# Altium schematic sheet Controller Board - Connectors.SchDoc("Controller Board - Connectors")
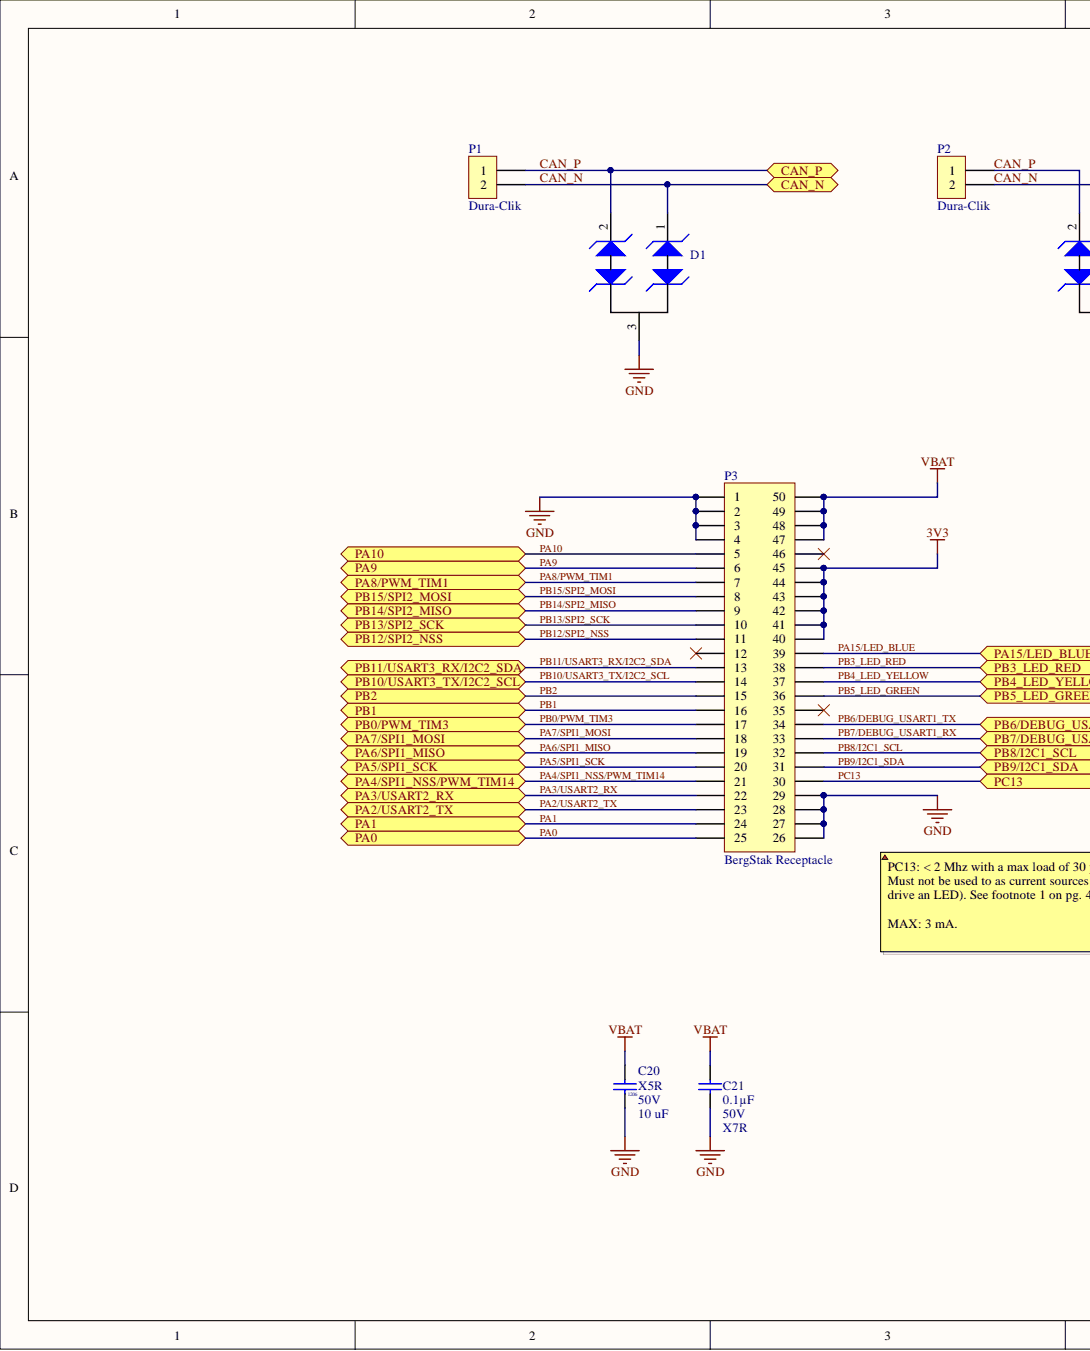
[diagram p0: Controller Board - Connectors.SchDoc, left]
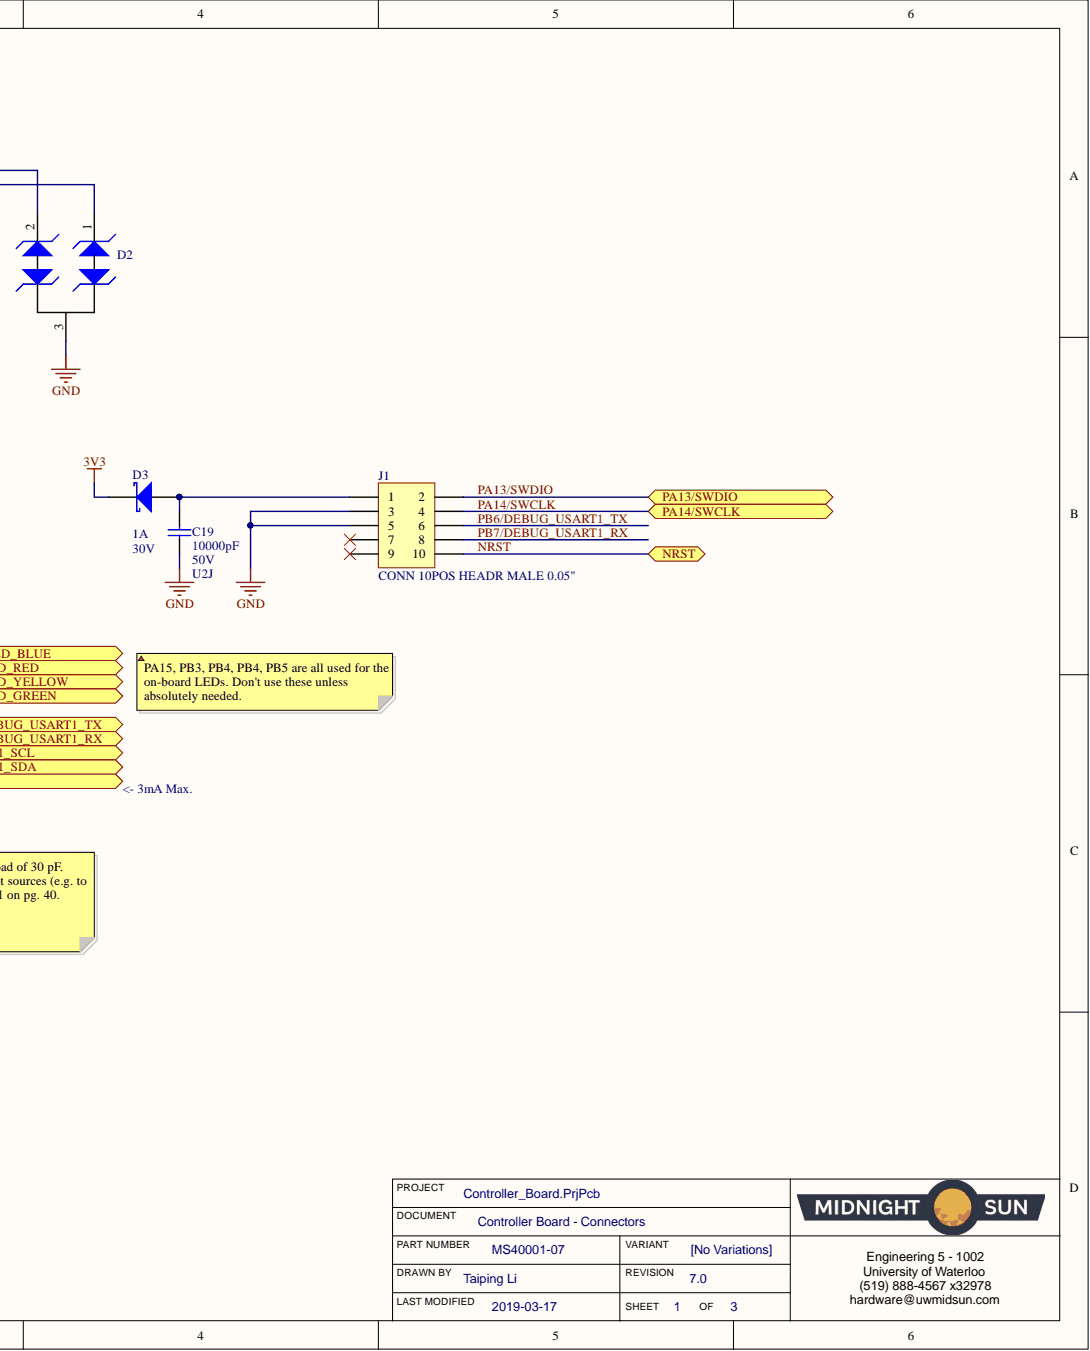
[diagram p1: Controller Board - Connectors.SchDoc, right]

## Parts
C19  Voltage: 50V  Temperature Coefficient: U2J  Capacitance: 10000pF  [CAP, 0603]  (hidden comment: CAP CER 10nF 50V 5% X7R 0603)
C20  Voltage Rating: 50V  Rating: X5R  Case Code (Imperial): 1206  Capacitance: 10 uF  [CAP, 1206]  (hidden comment: CAP CER 10uF 50V 20% X5R 1206)
C21  Voltage: 50V  Temperature Coefficient: X7R  Capacitance: 0.1µF  [CAP, 0603]  (hidden comment: CAP CER 0.1UF 50V 10% X7R 0603)
D1  DIODE TVS 24VWM 70VC SOT23  [MOSFET P-CH 30V 3.8A SOT-23]  (value not drawn)
D2  DIODE TVS 24VWM 70VC SOT23  [MOSFET P-CH 30V 3.8A SOT-23]  (value not drawn)
D3  Current - Average Rectified (Io): 1A  [DIODE SCHOTTKY 30V 1A POWERDI123]  (hidden comment: DIODE SCHOTTKY 30V 1A POWERDI123)
J1  CONN 10POS HEADR MALE 0.05"  [CONN, 10POS 0.05" Debug Header]
P1  Dura-Clik  [CONN, 2POS Dura-Click Vertical]
P2  Dura-Clik  [CONN, 2POS Dura-Click Vertical]
P3  Series: BergStak Receptacle  [CONN, Bergstak 50 Pos Receptacle]  (hidden comment: CONN 50POS Bergstak Receptacle 0.02")

## Ports
CAN_N, CAN_P, NRST, PA0, PA1, PA2/USART2_TX, PA3/USART2_RX, PA4/SPI1_NSS/PWM_TIM14, PA5/SPI1_SCK, PA6/SPI1_MISO, PA7/SPI1_MOSI, PA8/PWM_TIM1, PA9, PA10, PA13/SWDIO, PA14/SWCLK, PA15/LED_BLUE, PB0/PWM_TIM3, PB1, PB2, PB3_LED_RED, PB4_LED_YELLOW, PB5_LED_GREEN, PB6/DEBUG_USART1_TX, PB7/DEBUG_USART1_RX, PB8/I2C1_SCL, PB9/I2C1_SDA, PB10/USART3_TX/I2C2_SCL, PB11/USART3_RX/I2C2_SDA, PB12/SPI2_NSS, PB13/SPI2_SCK, PB14/SPI2_MISO, PB15/SPI2_MOSI, PC13

## Nets
3V3: D3.2 P3.40 P3.41 P3.42 P3.43 P3.44 P3.45
GND: C19.2 C20.2 C21.2 D1.3 D2.3 J1.3 J1.5 P3.1 P3.2 P3.3 P3.4 P3.26 P3.27 P3.28 P3.29
VBAT: C20.1 C21.1 P3.47 P3.48 P3.49 P3.50
CAN_N: D1.1 D2.1 P1.2 P2.2 ; port CAN_N
CAN_P: D1.2 D2.2 P1.1 P2.1 ; port CAN_P
NRST: J1.10 ; port NRST
PA0: P3.25 ; port PA0
PA1: P3.24 ; port PA1
PA2/USART2_TX: P3.23 ; port PA2/USART2_TX
PA3/USART2_RX: P3.22 ; port PA3/USART2_RX
PA4/SPI1_NSS/PWM_TIM14: P3.21 ; port PA4/SPI1_NSS/PWM_TIM14
PA5/SPI1_SCK: P3.20 ; port PA5/SPI1_SCK
PA6/SPI1_MISO: P3.19 ; port PA6/SPI1_MISO
PA7/SPI1_MOSI: P3.18 ; port PA7/SPI1_MOSI
PA8/PWM_TIM1: P3.7 ; port PA8/PWM_TIM1
PA9: P3.6 ; port PA9
PA10: P3.5 ; port PA10
PA13/SWDIO: J1.2 ; port PA13/SWDIO
PA14/SWCLK: J1.4 ; port PA14/SWCLK
PA15/LED_BLUE: P3.39 ; port PA15/LED_BLUE
PB0/PWM_TIM3: P3.17 ; port PB0/PWM_TIM3
PB1: P3.16 ; port PB1
PB2: P3.15 ; port PB2
PB3_LED_RED: P3.38 ; port PB3_LED_RED
PB4_LED_YELLOW: P3.37 ; port PB4_LED_YELLOW
PB5_LED_GREEN: P3.36 ; port PB5_LED_GREEN
PB6/DEBUG_USART1_TX: J1.6 P3.34 ; port PB6/DEBUG_USART1_TX
PB7/DEBUG_USART1_RX: J1.8 P3.33 ; port PB7/DEBUG_USART1_RX
PB8/I2C1_SCL: P3.32 ; port PB8/I2C1_SCL
PB9/I2C1_SDA: P3.31 ; port PB9/I2C1_SDA
PB10/USART3_TX/I2C2_SCL: P3.14 ; port PB10/USART3_TX/I2C2_SCL
PB11/USART3_RX/I2C2_SDA: P3.13 ; port PB11/USART3_RX/I2C2_SDA
PB12/SPI2_NSS: P3.11 ; port PB12/SPI2_NSS
PB13/SPI2_SCK: P3.10 ; port PB13/SPI2_SCK
PB14/SPI2_MISO: P3.9 ; port PB14/SPI2_MISO
PB15/SPI2_MOSI: P3.8 ; port PB15/SPI2_MOSI
PC13: P3.30 ; port PC13
NetC19_1: C19.1 D3.1 J1.1

Not connected: J1 7 9; P3 12 35 46

## Library data of the parts
C19 = CAP CER 10nF 50V 5% X7R 0603: 10000pF ±5% 50V Ceramic Capacitor U2J 0603 (1608 Metric); Manufacturer Part Number 1: C0603C103J5JAC7867; Manufacturer 1: KEMET; Tolerance: ±5%; Thickness (Max): 0.034" (0.87mm); Supplier Part Number 1: 399-13384-1-ND; Supplier 1: Digi-Key; Size / Dimension: 0.063" L x 0.032" W (1.60mm x 0.80mm); Ratings: -; Part Status: Active; Packaging: Cut Tape (CT); Package / Case: 0603 (1608 Metric); Operating Temperature: -55°C ~ 125°C; Mounting Type: Surface Mount, MLCC; Lead Style: -; Lead Spacing: -; Height - Seated (Max): -; Failure Rate: -; ComponentLink1URL: http://www.kemet.com/docfinder?Partnumber=C0603C103J5JAC7867; ComponentLink1Description: http://www.kemet.com/docfinder?Partnumber=C0603C103J5JAC7867; Component Kind: Standard; Library Name: Schematic Diagrams.SchLib
C20 = CAP CER 10uF 50V 20% X5R 1206: 10µF ±20% 50V Ceramic Capacitor X5R 1206 (3216 Metric); Manufacturer Part Number: GRT31CR61H106ME01L; Manufacturer: Murata; Width: 0.063inch; Tolerance: 20%; Thickness: 0.071inch; Supplier Part Number 1: 490-12457-1-ND; Supplier 1: Digi-Key; Stock 1: 449467; RoHS: Yes; Ratings: AEC-Q200; Pricing 1: 1 = 0.57000, 10 = 0.39800, 100 = 0.23910, 500 = 0.17930, 1000 = 0.15141 (USD); Packaging: Tape and Reel; Mount: Surface Mount; Min Operating Temperature: -55°C; Max Operating Temperature: 85°C; Length: 0.126inch; Component Kind: Standard; Case Code (Metric): 3216; Case/Package: 1206; Library Name: Schematic Diagrams.SchLib
C21 = CAP CER 0.1UF 50V 10% X7R 0603: 0.10µF ±10% 50V Ceramic Capacitor X7R 0603 (1608 Metric); Manufacturer Part Number 1: 06035C104KAT2A; Manufacturer 1: AVX Corporation; Tolerance: ±10%; Thickness (Max): 0.035" (0.90mm); Supplier Part Number 1: 478-5052-1-ND; Supplier 1: Digi-Key; Size / Dimension: 0.063" L x 0.032" W (1.60mm x 0.81mm); Ratings: -; Part Status: Active; Packaging: Cut Tape (CT); Package / Case: 0603 (1608 Metric); Operating Temperature: -55°C ~ 125°C; Mounting Type: Surface Mount, MLCC; Lead Style: -; Lead Spacing: -; Height - Seated (Max): -; ComponentLink1URL: http://datasheets.avx.com/X7RDielectric.pdf; ComponentLink1Description: http://datasheets.avx.com/X7RDielectric.pdf; Component Kind: Standard; Library Name: Schematic Diagrams.SchLib
D1 D2 = DIODE TVS 24VWM 70VC SOT23: TVS DIODE 24VWM 70VC SOT23; Manufacturer Part Number 1: PESD1CAN,215; Manufacturer 1: Nexperia USA Inc.; Voltage - Clamping (Max) @ Ipp: 70V; Voltage - Breakdown (Min): 25.4V; Type: Zener; Power Line Protection: No; Power - Peak Pulse: 200W; Current - Peak Pulse (10/1000µs): 3A (8/20µs); Capacitance @ Frequency: 11pF @ 1MHz; Supplier Part Number 1: 1727-3817-1-ND; Supplier Device Package: SOT-23 (TO-236AB); Supplier 1: Digi-Key; Part Status: Active; Packaging: Cut Tape (CT); Package / Case: TO-236-3, SC-59, SOT-23-3; Operating Temperature: -65°C ~ 150°C (TA); Mounting Type: Surface Mount; ComponentLink1URL: http://assets.nexperia.com/documents/data-sheet/PESD1CAN.pdf; ComponentLink1Description: http://assets.nexperia.com/documents/data-sheet/PESD1CAN.pdf; Component Kind: Standard; Library Name: Schematic Diagrams.SchLib
D3 = DIODE SCHOTTKY 30V 1A POWERDI123: Diode Schottky 30V 1A Surface Mount PowerDI™ 123; Manufacturer Part Number: DFLS130L-7; Manufacturer: Diodes Incorporated; Voltage - Forward (Vf) (Max) @ If: 310mV @ 1A; Speed: Fast Recovery =< 500ns, > 200mA (Io); Current - Reverse Leakage @ Vr: 1mA @ 30V; Capacitance @ Vr, F: 76pF @ 10V, 1MHz; Voltage - DC Reverse (Vr) (Max): 30V; Supplier Part Number 1: DFLS130LDICT-ND; Supplier Device Package: PowerDI™ 123; Supplier 1: Digi-Key; Stock 1: 68200; RoHS: RoHS Compliant; Pricing 1: 1=0.7, 10=0.599, 100=0.447, 500=0.35126, 1000=0.27142 (CAD); Part Status: Active; Packaging: Cut Tape (CT); Package / Case: POWERDI®123; Operating Temperature - Junction: -40°C ~ 125°C; Mounting Type: Surface Mount; Diode Type: Schottky; ComponentLink1URL: https://www.diodes.com/assets/Datasheets/ds30492.pdf; ComponentLink1Description: https://www.diodes.com/assets/Datasheets/ds30492.pdf; Component Kind: Standard; Category: Discrete Semiconductor Products
J1 = CONN 10POS HEADR MALE 0.05": 10 Positions Header Connector 0.050" (1.27mm) Surface Mount Gold; Manufacturer Part Number 1: 20021121-00010C4LF; Manufacturer 1: Amphenol FCI; Style: Board to Board; Shrouding: Unshrouded; Number of Positions Loaded: All; Number of Positions: 10; Material Flammability Rating: UL94 V-0; Contact Length - Mating: 0.120" (3.05mm); Voltage: -; Termination: Solder; Supplier Part Number 1: 609-3695-1-ND; Supplier 1: Digi-Key; Row Spacing - Mating: 0.050" (1.27mm); Pitch - Mating: 0.050" (1.27mm); Part Status: Active; Packaging: Cut Tape (CT); Overall Contact Length: -; Operating Temperature: -; Number of Rows: 2; Mounting Type: Surface Mount; Mated Stacking Heights: -; Insulation Material: Thermoplastic; Insulation Height: -; Insulation Color: Black; Ingress Protection: -; Fastening Type: -; Current Rating: -; Contact Type: Male Pin; Contact Shape: Square; Contact Material: Copper Alloy; Contact Length - Post: -; Contact Finish Thickness - Post: 50µin (1.27µm); Contact Finish Thickness - Mating: 10µin (0.25µm); Contact Finish - Post: Tin; Contact Finish - Mating: Gold; Connector Type: Header; ComponentLink1URL: http://portal.fciconnect.com/Comergent//fci/drawing/20021121.pdf; ComponentLink1Description: http://portal.fciconnect.com/Comergent//fci/drawing/20021121.pdf; Component Kind: Standard; Library Name: Schematic Diagrams.SchLib
P1 P2 = CONN 2POS DURA-CLIK 0.079" VERT: 2 Positions Header, Shrouded Connector 0.079" (2.00mm) Surface Mount, Vertical Tin; Manufacturer Part Number: 5600200220; Manufacturer: Molex, LLC; Style: Board to Cable/Wire; Shrouding: Shrouded - 4 Wall; Number of Positions Loaded: All; Number of Positions: 2; Mated Stacking Heights: 9.3mm; Voltage Rating: -; Termination: Solder; Supplier Part Number 1: WM10862CT-ND; Supplier 1: Digi-Key; Stock 1: 11912; Row Spacing - Mating: -; RoHS: RoHS Compliant; Pricing 1: 1=1.16, 10=1.012, 25=0.952, 50=0.9122, 100=0.8727, 250=0.79336 (CAD); Pitch - Mating: 0.079" (2.00mm); Part Status: Active; Packaging: Cut Tape (CT); Overall Contact Length: -; Operating Temperature: -; Number of Rows: 1; Mounting Type: Surface Mount; Material Flammability Rating: -; Insulation Material: Polyamide (PA), Nylon; Insulation Height: 0.315" (8.00mm); Insulation Color: Natural; Ingress Protection: -; Features: Solder Retention; Fastening Type: Latch Lock; Current Rating: -; Contact Type: Male Pin; Contact Shape: Square; Contact Material: Copper Alloy; Contact Length - Post: -; Contact Length - Mating: -; Contact Finish Thickness - Post: -; Contact Finish Thickness - Mating: -; Contact Finish - Post: Tin; Contact Finish - Mating: Tin; Connector Type: Header; ComponentLink1URL: http://www.molex.com/pdm_docs/sd/5600200220_sd.pdf; ComponentLink1Description: http://www.molex.com/pdm_docs/sd/5600200220_sd.pdf; Component Kind: Standard; Category: Connectors, Interconnects; Applications: Automotive, Industrial; Library Name: Schematic Diagrams.SchLib
P3 = CONN 50POS Bergstak Receptacle 0.02": 50 Position Connector Receptacle, Center Strip Contacts Surface Mount Gold; Manufacturer Part Number 1: 10132798-052100LF; Manufacturer 1: Amphenol FCI; Pitch: 0.020" (0.50mm); Number of Positions: 50; Mated Stacking Heights: 5mm, 5.5mm, 6mm; Supplier Part Number 1: 609-5227-1-ND; Supplier 1: Digi-Key; Part Status: Active; Packaging: Cut Tape (CT); Number of Rows: 2; Mounting Type: Surface Mount; Height Above Board: 0.106" (2.70mm); Contact Finish Thickness: 1µin (0.025µm); Contact Finish: Gold; Connector Type: Receptacle, Center Strip Contacts; ComponentLink1URL: http://portal.fciconnect.com/Comergent//fci/drawing/10132798.pdf; ComponentLink1Description: http://portal.fciconnect.com/Comergent//fci/drawing/10132798.pdf; Component Kind: Standard; Library Name: Schematic Diagrams.SchLib
